# Altium schematic sheet Date.SchDoc
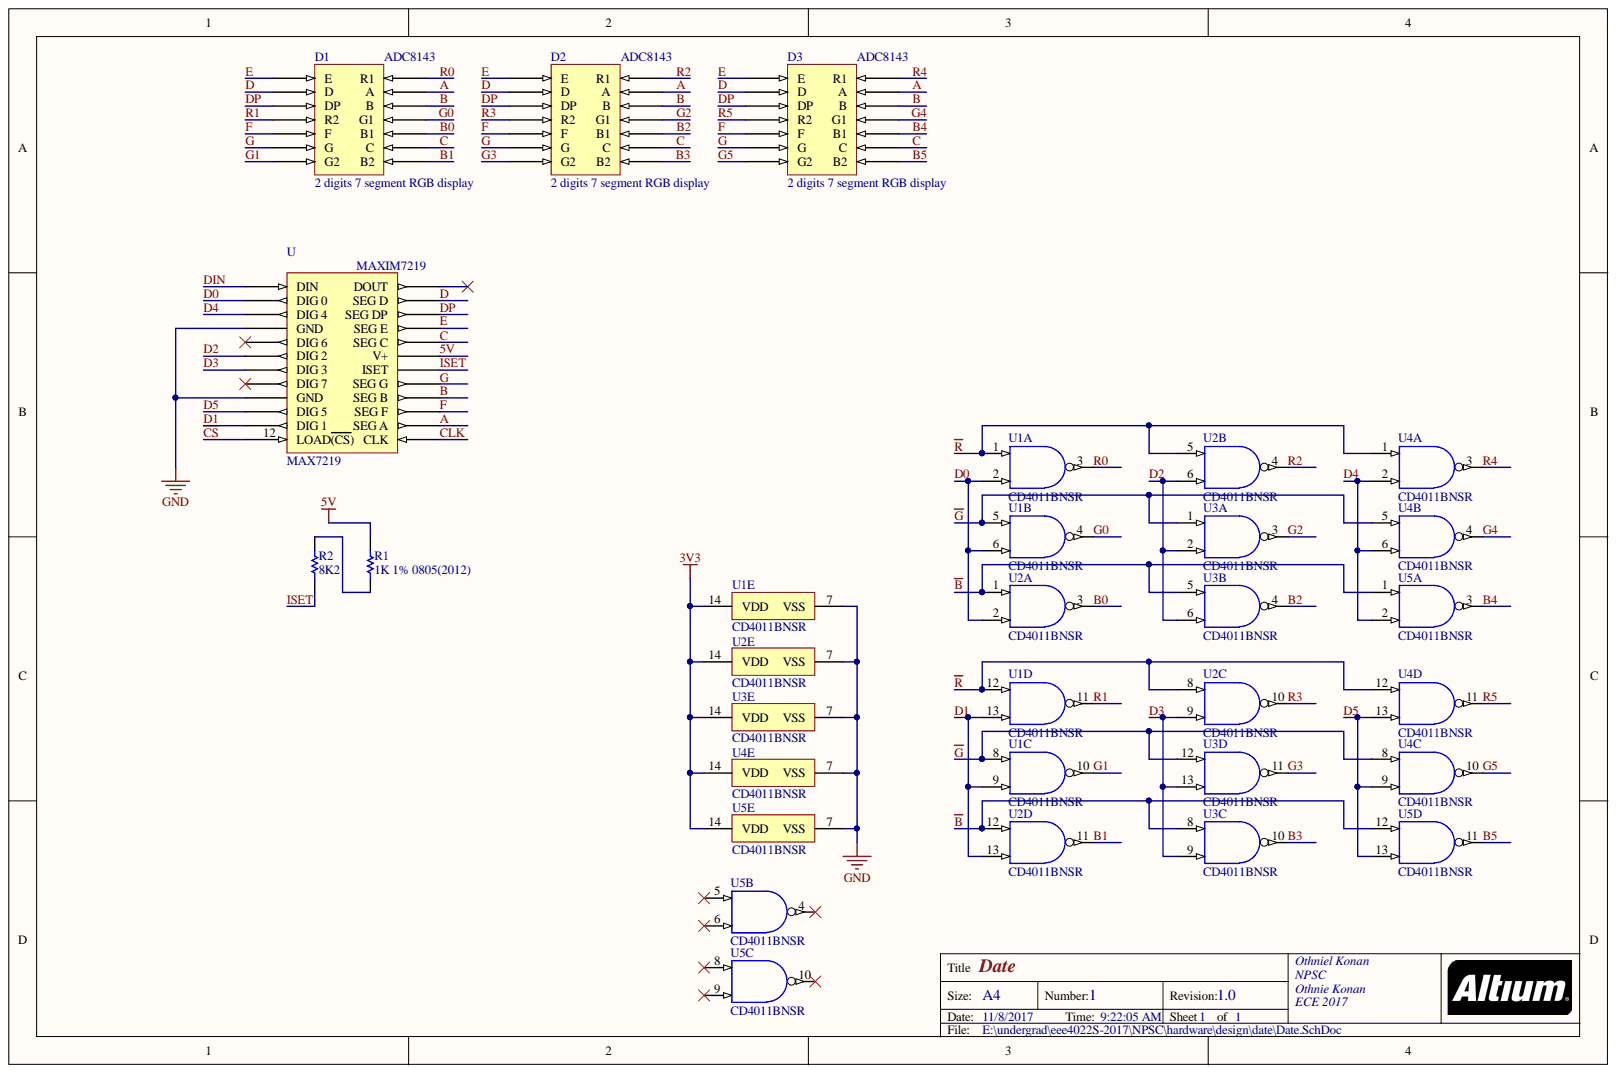
[diagram p0: Date.SchDoc]

## Parts
D1  2 digits 7 segment RGB display  [ACD8143]
D2  2 digits 7 segment RGB display  [ACD8143]
D3  2 digits 7 segment RGB display  [ACD8143]
R1  1K 1% 0805(2012)  [RESC0805(2012)_M]
R2  8K2  [RESC0805(2012)_M]
U  MAX7219  [SOIC127P1030X235-24N]
U1  CD4011BNSR  [NS0014A_N]  (parts A,B,C,D,E)
U2  CD4011BNSR  [NS0014A_N]  (parts A,B,C,D,E)
U3  CD4011BNSR  [NS0014A_N]  (parts A,B,C,D,E)
U4  CD4011BNSR  [NS0014A_N]  (parts A,B,C,D,E)
U5  CD4011BNSR  [NS0014A_N]  (parts A,B,C,D,E)

## Nets
3V3: U1.14(VDD) U2.14(VDD) U3.14(VDD) U4.14(VDD) U5.14(VDD)
GND: U.4(GND) U.9(GND) U1.7(VSS) U2.7(VSS) U3.7(VSS) U4.7(VSS) U5.7(VSS)
5V: R1.1 U.19(V+)
~{B}: U2.1 U2.12 U3.5 U3.8 U5.1 U5.12
~{CS}: U.12(LOAD(CS))
~{G}: U1.5 U1.8 U3.1 U3.12 U4.5 U4.8
~{R}: U1.1 U1.12 U2.5 U2.8 U4.1 U4.12
A: D1.13(A) D2.13(A) D3.13(A) U.14(SEG_A)
B: D1.12(B) D2.12(B) D3.12(B) U.16(SEG_B)
B0: D1.10(B1) U2.3
B1: D1.8(B2) U2.11
B2: D2.10(B1) U3.4
B3: D2.8(B2) U3.10
B4: D3.10(B1) U5.3
B5: D3.8(B2) U5.11
C: D1.9(C) D2.9(C) D3.9(C) U.20(SEG_C)
CLK: U.13(CLK)
D: D1.2(D) D2.2(D) D3.2(D) U.23(SEG_D)
D0: U.2(DIG_0) U1.2 U1.6 U2.2
D1: U.11(DIG_1) U1.9 U1.13 U2.13
D2: U.6(DIG_2) U2.6 U3.2 U3.6
D3: U.7(DIG_3) U2.9 U3.9 U3.13
D4: U.3(DIG_4) U4.2 U4.6 U5.2
D5: U.10(DIG_5) U4.9 U4.13 U5.13
DIN: U.1(DIN)
DP: D1.3(DP) D2.3(DP) D3.3(DP) U.22(SEG_DP)
E: D1.1(E) D2.1(E) D3.1(E) U.21(SEG_E)
F: D1.5(F) D2.5(F) D3.5(F) U.15(SEG_F)
G: D1.6(G) D2.6(G) D3.6(G) U.17(SEG_G)
G0: D1.11(G1) U1.4
G1: D1.7(G2) U1.10
G2: D2.11(G1) U3.3
G3: D2.7(G2) U3.11
G4: D3.11(G1) U4.4
G5: D3.7(G2) U4.10
ISET: R2.2 U.18(ISET)
R0: D1.14(R1) U1.3
R1: D1.4(R2) U1.11
R2: D2.14(R1) U2.4
R3: D2.4(R2) U2.10
R4: D3.14(R1) U4.3
R5: D3.4(R2) U4.11
NetR1_2: R1.2 R2.1

Not connected: U 5(DIG_6) 8(DIG_7) 24(DOUT); U5 4 5 6 8 9 10

## Library data of the parts
D1-D3 = ACD8143: Component Kind: Standard; Library Name: Integrated_Library_component.IntLib
R1 = CMP-1013-00954-1: 8M45 0.125W 1% 0805 (2012 Metric)  SMD; Case-EIA: 0805; Case-Metric: 2012; Value: 1000.00 Ohm; Component Kind: Standard; Library Name: Altium Content Vault; Power: 0.125 W; Technology: SMT; Tolerance: 1 %
R2 = CMP-1013-00954-1: 8K2 0.125W 1% 0805 (2012 Metric)  SMD; Case-EIA: 0805; Case-Metric: 2012; Value: 8200.00 Ohm; Component Kind: Standard; Library Name: Altium Content Vault; Power: 0.125 W; Technology: SMT; Tolerance: 1 %
U = MAX7219: Serial Interface, 8-Digit LED Display Drivers; Component Kind: Standard; Library Name: Integrated_Library_component.IntLib
U1-U5 = CMP-1627-00522-3: CMOS Quad 2-Input NAND Gate, NS0014A, LARGE T&R; Manufacturer: Texas Instruments; Bits(#): 4; F @ Nom Voltage(Max)(Mhz): 8; ICC @ Nom Voltage(Max)(mA): 0.015; Output Drive (IOL/IOH)(Max)(mA): 1.5/-1.5; Rating: Military; Schmitt Trigger: No; Technology Family: CD4000; VCC(Max)(V): 18; VCC(Min)(V): 3; Voltage(Nom)(V): 10; Component Kind: Standard; DatasheetVersion: SCHS021D; Generic Part Number: CD4011B; Input Type: CMOS; Library Name: Altium Content Vault; Operating Temperature Range(C): -55 to 125; Output Type: CMOS; PackageReference: NS0014A
